# Altium schematic sheet Power_CAN.SchDoc(Power_CAN)
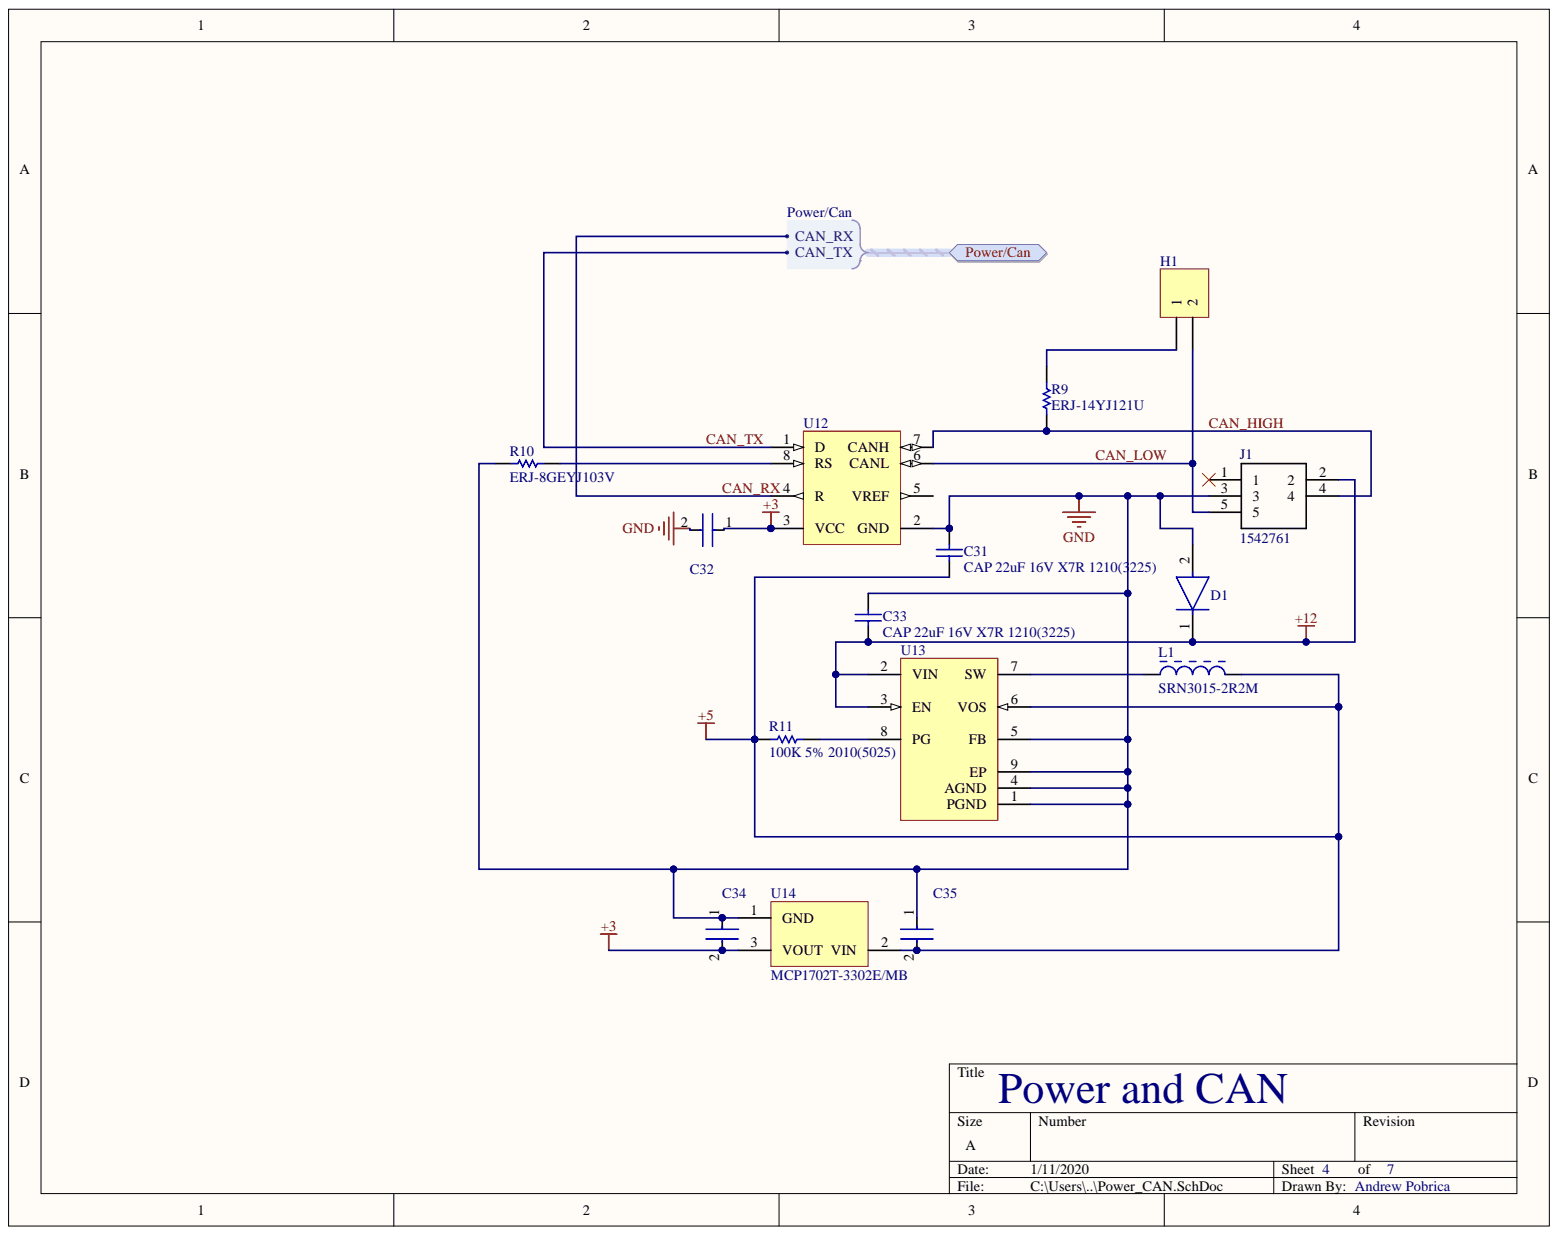
[diagram p0: Power_CAN.SchDoc]

## Parts
C31  CAP 22uF 16V X7R 1210(3225)  value 22uF  [CAPC1210(3225)280_L]
C32  C0603C104K5RACTU  [Kemet_C0805_IPC_A]  (value not drawn)
C33  CAP 22uF 16V X7R 1210(3225)  value 22uF  [CAPC1210(3225)280_L]
C34  C0805C105K4RACTU  [Kemet_C0805_IPC_A]  (value not drawn)
C35  C0805C105K4RACTU  [Kemet_C0805_IPC_A]  (value not drawn)
D1  S1J-13-F  [Diodes_SMA_0]  (value not drawn)
H1  Header 0  [HDR1X2]  (value not drawn)
J1  1542761  [1542761]
L1  SRN3015-2R2M  [BOUR-SRN3015-2_V]
R9  ERJ-14YJ121U  [RESC3225X70X50ML20T20]
R10  ERJ-8GEYJ103V  [RESC3216X70X50NL05T20]
R11  100K 5% 2010(5025)  [RESC2010(5025)_L]
U12  SN65HVD230D  [D0008A_M]  (value not drawn)
U13  TPS62173DSGR  [DSG8-1600X900TP]  (value not drawn)
U14  MCP1702T-3302E/MB  [SOT-89-MB3_N]

## Ports
Power/Can, Power/Can.CAN_RX, Power/Can.CAN_TX

## Nets
+3: C32.1 C34.2 U12.3(VCC) U14.3
+5: C31.1 C35.2 L1.2 R11.1 U13.6(VOS) U14.2
+12: C33.1 D1.1 J1.2 U13.2(VIN) U13.3(EN)
GND: C31.2 C32.2 C33.2 C34.1 C35.1 D1.2 J1.3 R10.2 U12.2(GND) U13.1(PGND) U13.4(AGND) U13.5(FB) U13.9(EP) U14.1
CAN_HIGH: J1.4 R9.1 U12.7(CANH)
CAN_LOW: H1.2 J1.5 U12.6(CANL)
CAN_RX: U12.4(R) ; port Power/Can
CAN_TX: U12.1(D) ; port Power/Can
NetH1_1: H1.1 R9.2
NetL1_1: L1.1 U13.7(SW)
NetR10_1: R10.1 U12.8(RS)
NetR11_2: R11.2 U13.8(PG)

Not connected: J1 1; U12 5(VREF)

## Library data of the parts
C31 C33 = CMP-1038-02277-1: CAP 22uF 16V ±10% 1210 (3225 Metric) Thickness 2.8mm SMD; Tolerance: Â±10%; Case-Metric: 3225; Case-EIA: 1210; Technology: SMT; Rated Voltage: 16 V; Max Thickness: 2.8 mm; Library Name: Altium Content Vault; Component Kind: Standard
  C33: Value: 22uF
H1 = Header 2: Header, 2-Pin; Signal Integrity: Connector; Library Name: Miscellaneous Connectors.IntLib; LatestRevisionNote: Re-released for DXP Platform.; LatestRevisionDate: 17-Jul-2002; Component Kind: Standard; Publisher: Altium Limited
J1 = 1542761: Connector; Manufacturer_Name: Phoenix Contact; Allied_Number: 70170366; RS Price/Stock: http://uk.rs-online.com/web/p/products/8025583; RS Part Number: 8025583; Mouser Price/Stock: https://www.mouser.com/Search/Refine.aspx?Keyword=651-1542761; Mouser Part Number: 651-1542761; Manufacturer_Part_Number: 1542761; Height: 18mm; Datasheet Link: https://media.digikey.com/PDF/Data%20Sheets/Phoenix%20Contact%20PDFs/1542761.pdf; Component Kind: Standard; Allied Price/Stock: https://www.alliedelec.com/phoenixcontact-1542761/70170366/
L1 = CMP-0007-00003-1: Semi-shielded Power Inductor, 2.2 uH, +/- 20%, 1.8 A, -40 to 125 degC, 3 x 3 x 1.5 mm SMD, RoHS and Halogen Free, Tape and Reel; Manufacturer: Bourns; SRF Min: 90 MHz; Resistance to Solder Heat: +260 degC for 10 sec.; Rated current: 1.8 A; Isat: 1.65 A; Inductance tolerance: +/- 20%; Inductance: 2.2 uH; DCR Max: 0.072 Ohm; RoHS: TRUE; PartNumber: SRN3015-2R2M; Packing: Tape and Reel; PackageReference: SRN3015; PackageDescription: 2-Pin SMD, Body 3 x 3 mm, Height 1.5 mm; Operating Temperature: -40 to 125 degC; Mounting Technology: Surface Mount; Library Name: Altium Content Vault; DatasheetVersion: Aug-11; ComponentLink3URL: http://www.bourns.com/data/global/pdfs/SRN3015.pdf; ComponentLink3Description: Package Specification; ComponentLink2URL: http://www.bourns.com/data/global/pdfs/SRN3015.pdf; ComponentLink2Description: Datasheet; ComponentLink1URL: http://www.bourns.com/; ComponentLink1Description: Manufacturer URL; Component Kind: Standard
R9 = CMP-2005-03490-1: Manufacturer: Panasonic; Part Number: ERJ-14YJ121U; Library Name: Altium Content Vault; Component Kind: Standard
R10 = CMP-2003-00545-1: Manufacturer: Panasonic; Part Number: ERJ-8GEYJ103V; Library Name: Altium Content Vault; Component Kind: Standard
R11 = CMP-1017-00122-1: 100K 0.75W 5% 2010 (5025 Metric)  SMD; Value: 100000.00 Ohm; Case-Metric: 5025; Case-EIA: 2010; Tolerance: 5 %; Technology: SMT; Power: 0.75 W; Library Name: Altium Content Vault; Component Kind: Standard
U12 = CMP-0315-00046-3: CAN Bus Transceiver, 3.3 V, -40 to 85 degC, 8-Pin SOIC (D), Green (RoHS & no Sb/Br), Tube; Supply Voltage Min: 3 V; Supply Voltage Max: 3.6 V; Temperature Min: -40 degC; Temperature Max: 85 degC; RoHS: TRUE; PartNumber: SN65HVD230D; Packing: Tube; PackageDescription: 8-Pin Plastic Small Outline, Body 4.9 x 3.9 mm, Pitch 1.27 mm; Mounting Technology: Surface Mount; Library Name: Altium Content Vault; Ibis Model: HVD230D; Generic Part Number: SN65HVD230; DatasheetVersion: SLOS346N; ComponentLink2URL: http://www.ti.com/general/docs/lit/getliterature.tsp?genericGeneric Part Number=SN65HVD230&fileType=pdf; ComponentLink2Description: Datasheet; ComponentLink1URL: http://www.ti.com/; ComponentLink1Description: Manufacturer URL; Component Kind: Standard
U13 = CMP-0323-00320-3: Buck Step Down Regulator with 3 to 17 V Input and 5 V Output, -40 to 85 degC, 8-Pin WSON (DSG), Green (RoHS & no Sb/Br); Manufacturer: Texas Instruments; Vout(Min)(V): 5; Vin(Min)(V): 3; Vin(Max)(V): 17; Synchronous Rectification: TRUE; Switch Current Limit(Typ)(A): 1.05; SubFamily: Step-Down Regulator; Regulated Outputs(#): 1; Rating: Catalog; Iq(Typ)(mA): 0.017; Iout(Max)(A): 0.5; Duty Cycle(Max)(%): 100; Vout(Max)(V): 5; Topology: Buck; Simulation: Model Name; Preset Vout(V): 5; PartNumber: TPS62173DSGR; PackageVersion: revB, Oct-2010; PackageReference: DSG0008A; PackageDescription: 8-Pin Plastic Small Outline No-Lead, 2 x 2 x 0.8 mm Body, 0.5 mm Pitch, Exposed Pad; Mounting Technology: Surface Mount; Library Name: Components - 19.05.19 - 16.01.SchLib; Eco-Plan: Green (RoHS & no Sb/Br); DatasheetVersion: SLVSAT8A; ComponentLink3URL: Samples URL:http://www.ti.com/product/tps62173#samples; ComponentLink3Description: URL Link1; ComponentLink2URL: http://www.ti.com/litv/pdf/mpds308a; ComponentLink2Description: Package Specification; ComponentLink1URL: http://www.ti.com/general/docs/lit/getliterature.tsp?genericPartNumber=TPS62173&fileType=pdf; ComponentLink1Description: Datasheet; Component Kind: Standard; Code_JEDEC: MO-229
U14 = CMP-0136-00852-1: 250 mA Low Quiescent Current LDO Regulator, 3-Pin SOT-89, Extended Temperature, Tape and Reel; PackageVersion: revBB, Aug-2009; PackageReference: SOT-89-MB3; PackageDescription: 3-Lead Plastic Small Outline Transistor Header (MB) [SOT-89]; Library Name: Altium Content Vault; DatasheetVersion: revD, Jun-2009; Component Kind: Standard; Code_IPC: SOT89
C32 = C0603C104K5RACTU: Component Kind: Standard; Library Name: parts/UNK_Kemet_C0603C104K5RACTU_eec.SchLib
C34 C35 = C0805C105K4RACTU: Library Name: parts/Cap_Kemet_C0805C105K4RACTU_eec.SchLib; Component Kind: Standard
D1 = S1J-13-F: Library Name: Diode_Diodes_S1J-13-F_eec.SchLib; Component Kind: Standard
